# Altium schematic sheet NU-PROG_ESP32.SchDoc(NU-PROG_ESP32)
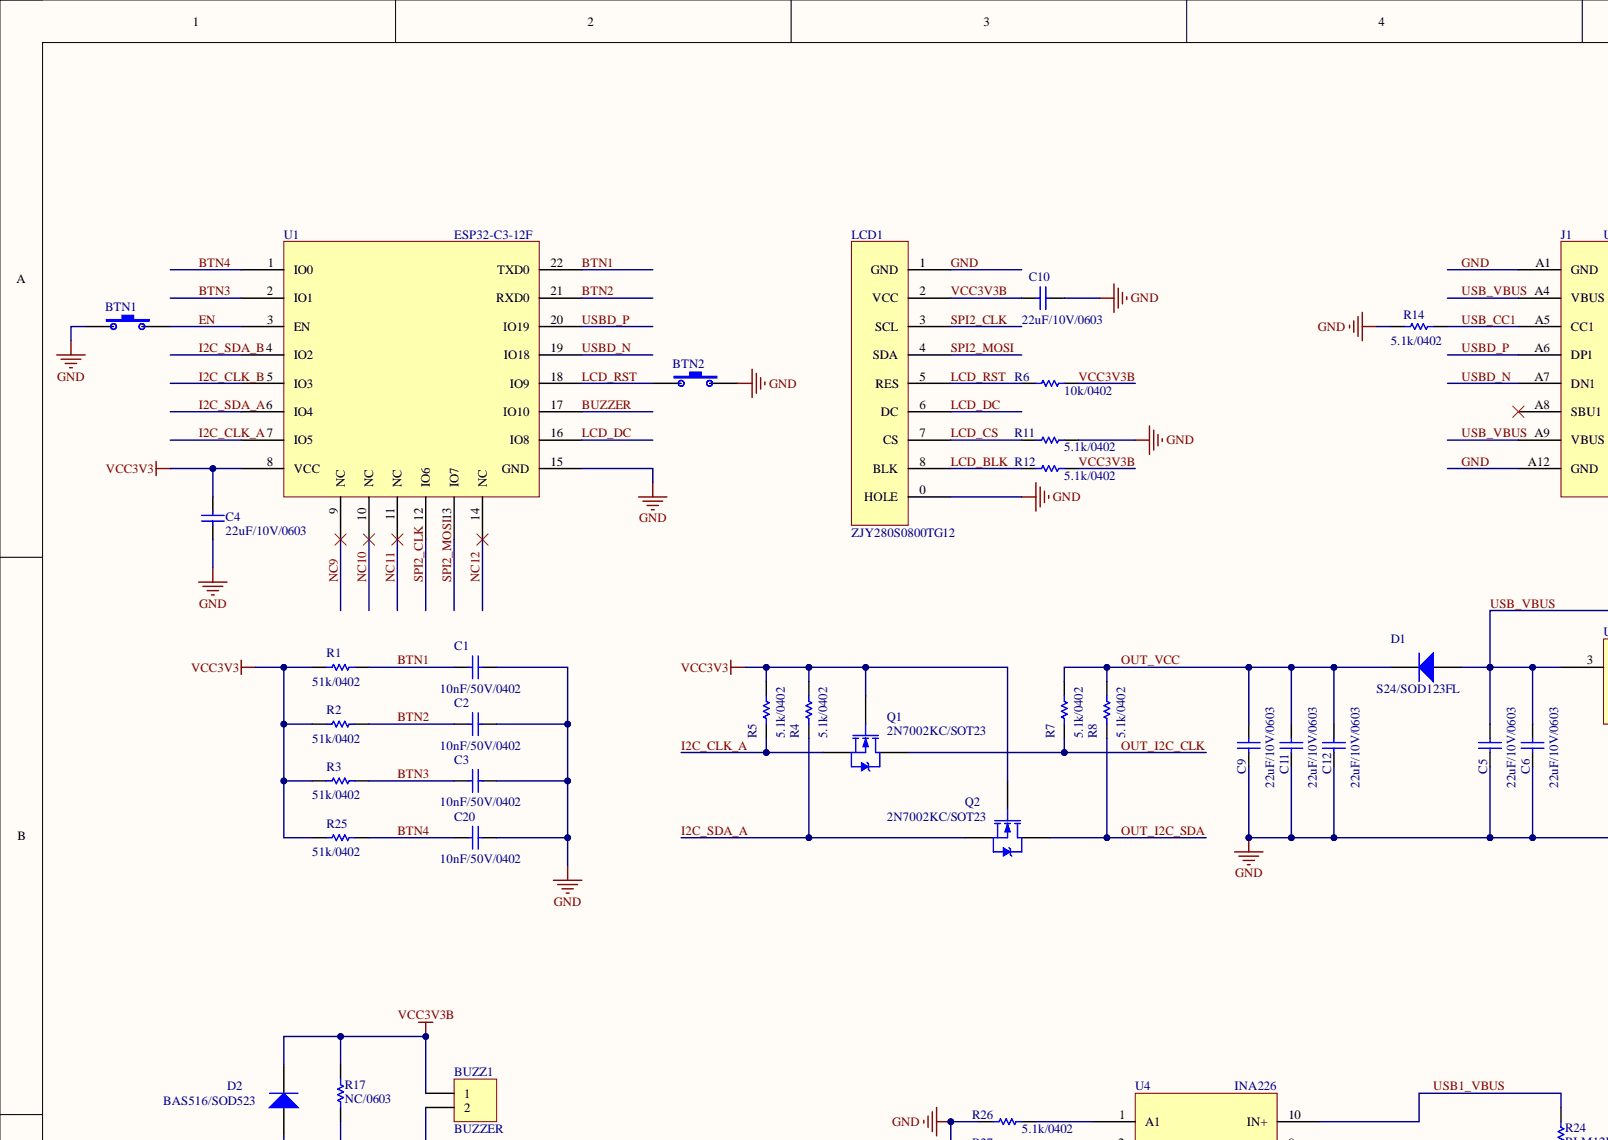
[diagram p0: NU-PROG_ESP32.SchDoc, top left]
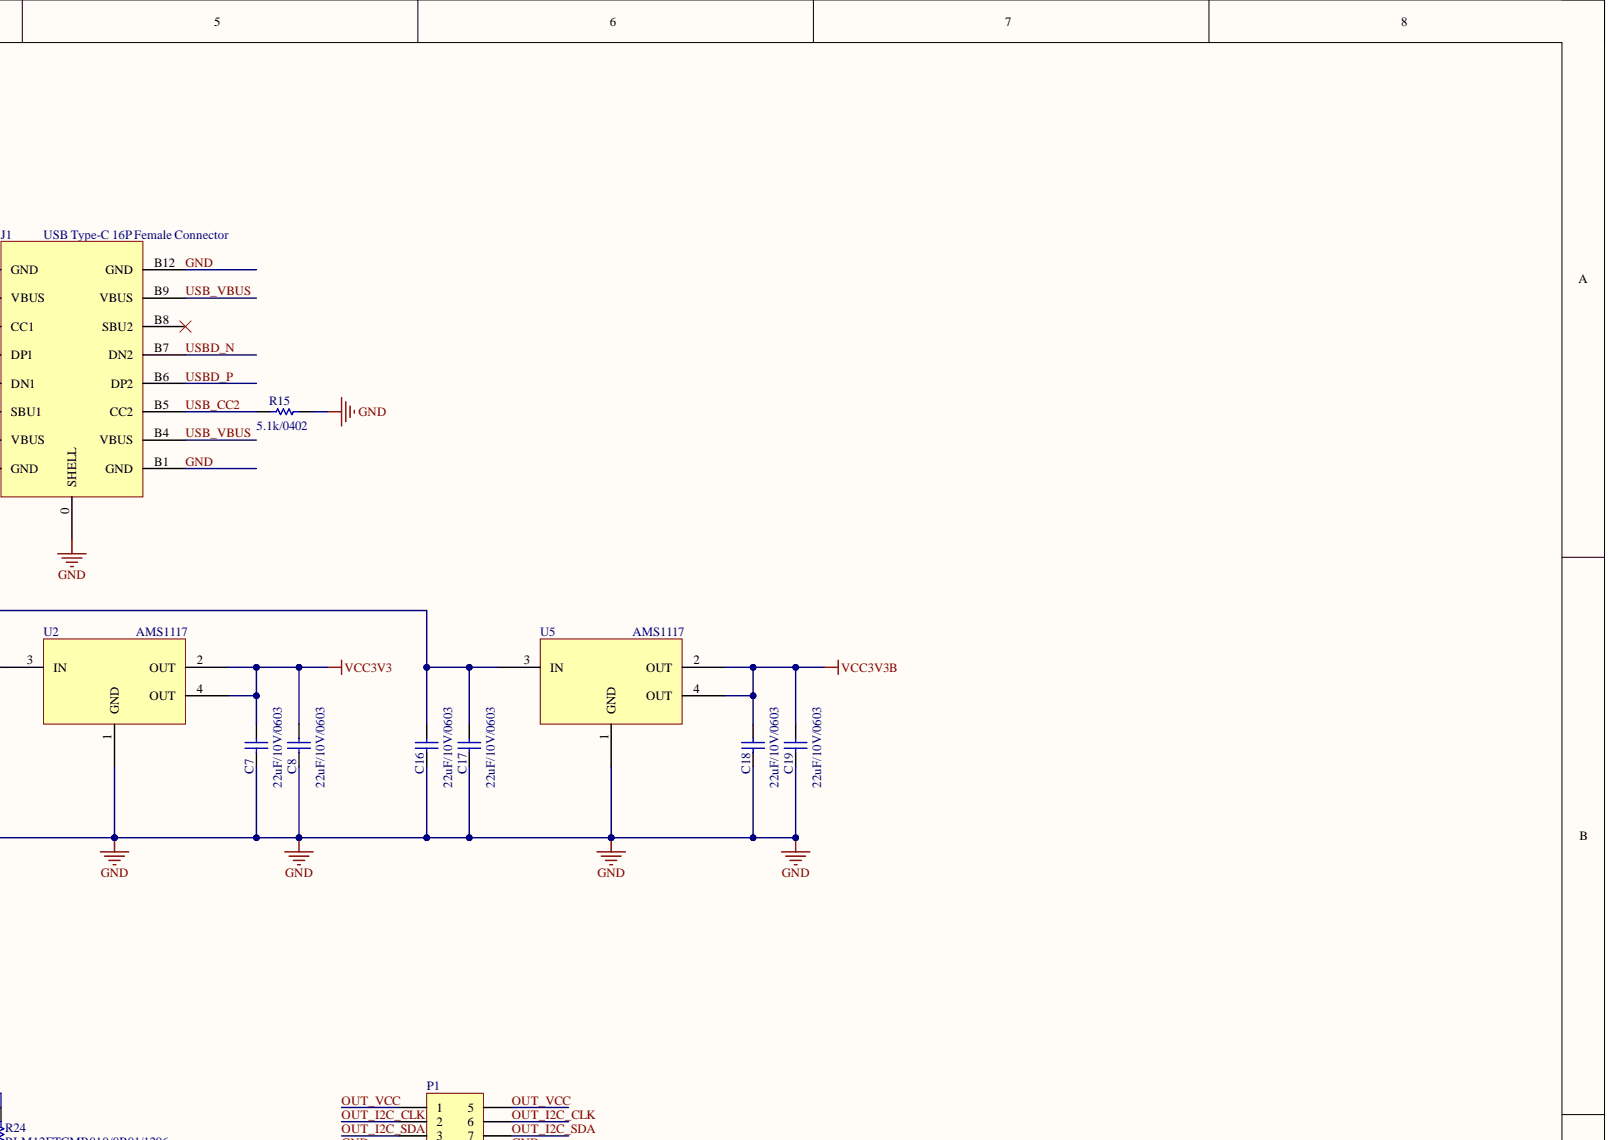
[diagram p1: NU-PROG_ESP32.SchDoc, top right]
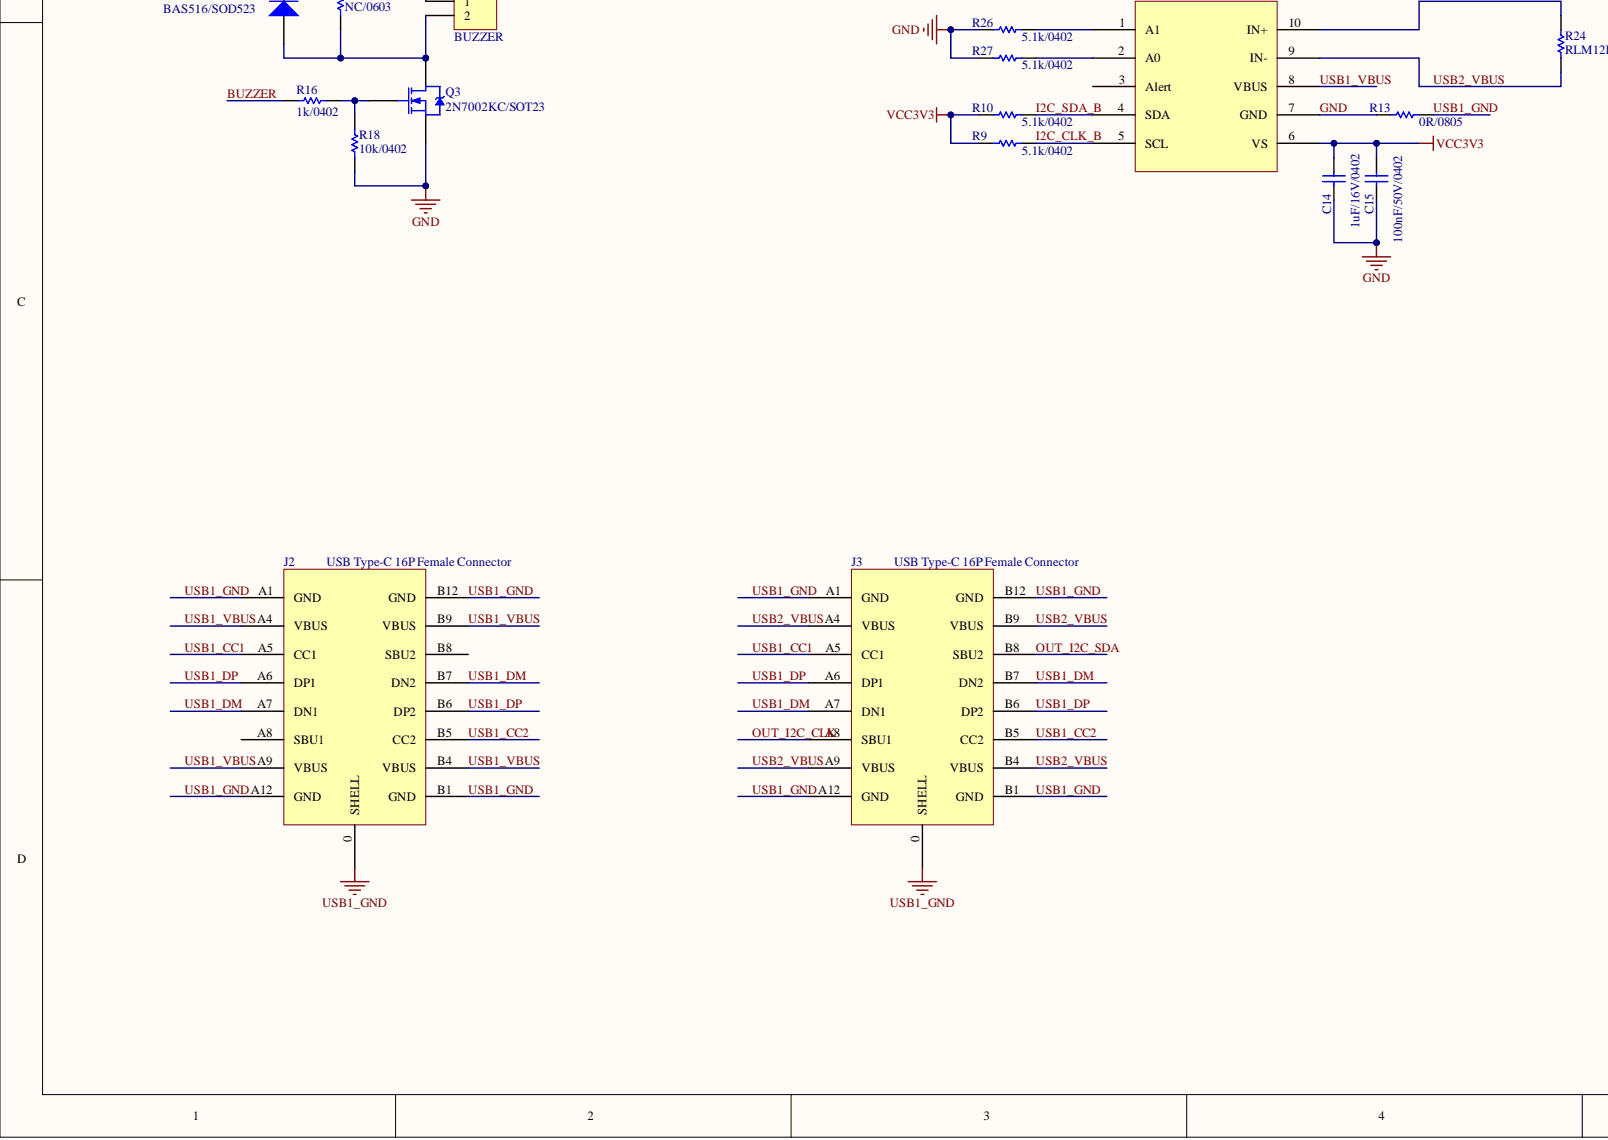
[diagram p2: NU-PROG_ESP32.SchDoc, bottom left]
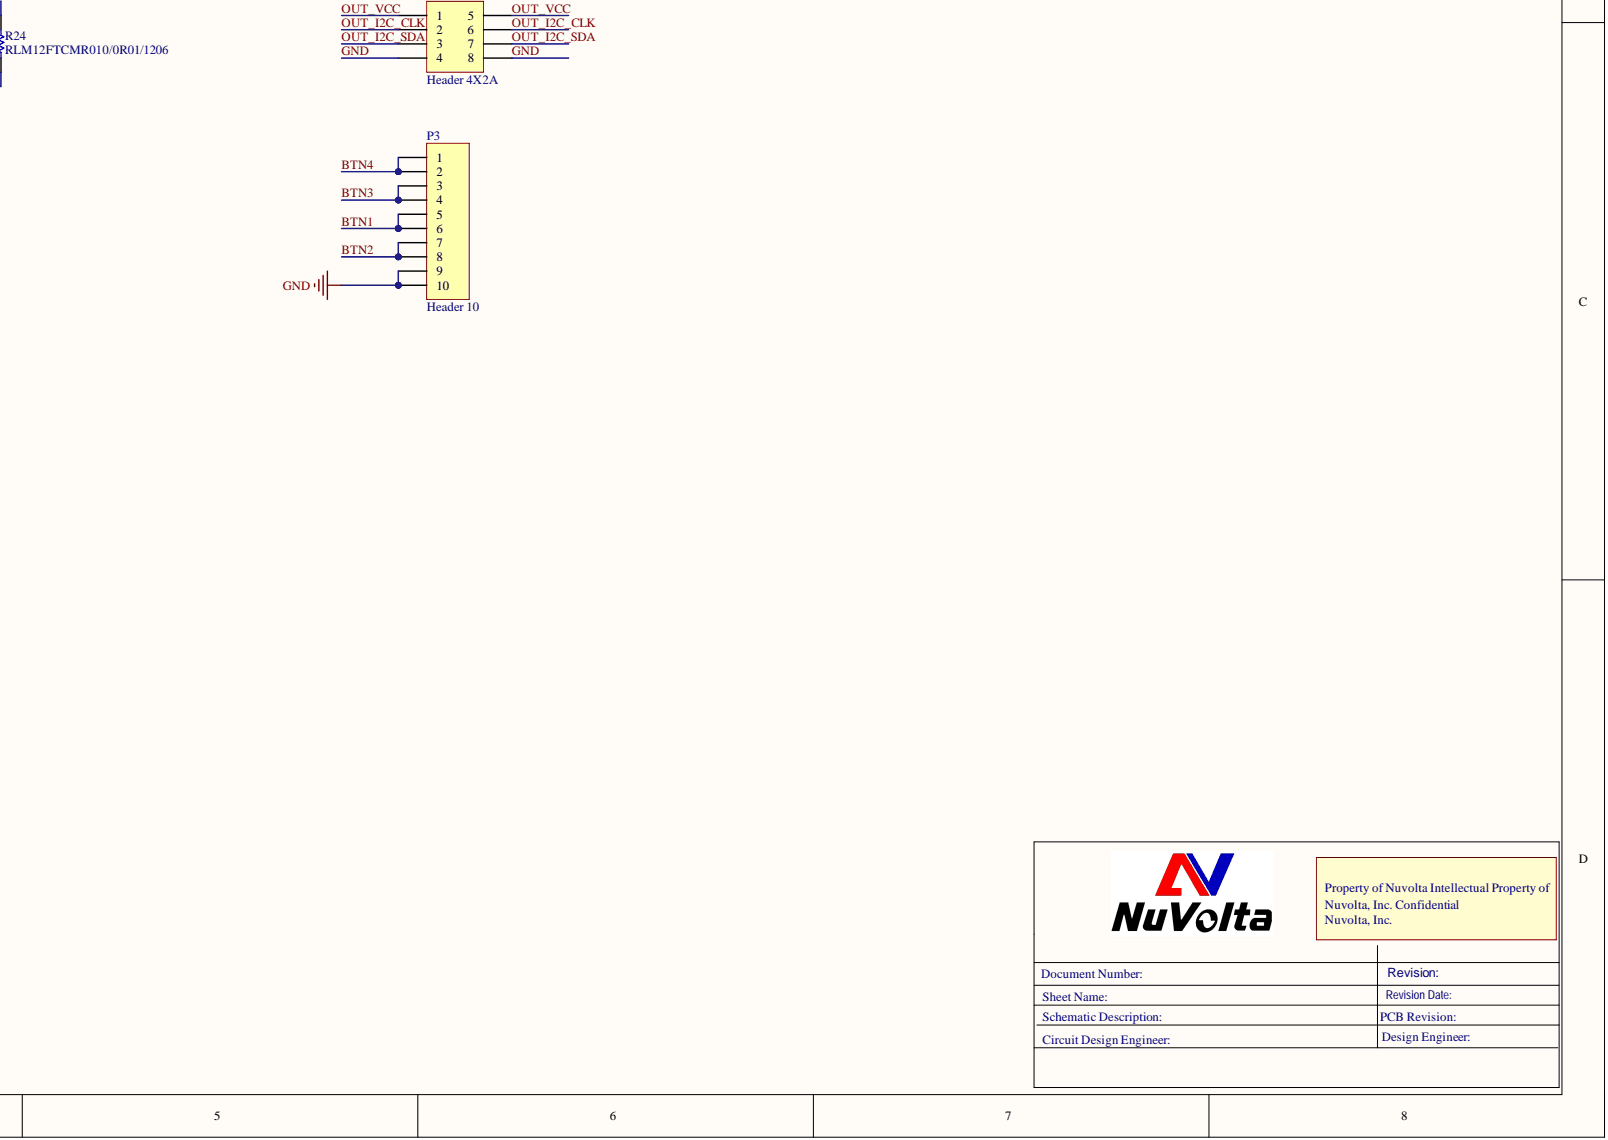
[diagram p3: NU-PROG_ESP32.SchDoc, bottom right]

## Parts
BTN1  Button/GT-TC029X-H020-L1N  [GT-TC029X-H020]  (value not drawn)
BTN2  Button/GT-TC029X-H020-L1N  [GT-TC029X-H020]  (value not drawn)
BUZZ1  BUZZER  [PS1240P02CT3]
C1  10nF/50V/0402  [C0402]
C2  10nF/50V/0402  [C0402]
C3  10nF/50V/0402  [C0402]
C4  22uF/10V/0603  [C0603]
C5  22uF/10V/0603  [C0603]
C6  22uF/10V/0603  [C0603]
C7  22uF/10V/0603  [C0603]
C8  22uF/10V/0603  [C0603]
C9  22uF/10V/0603  [C0603]
C10  22uF/10V/0603  [C0603]
C11  22uF/10V/0603  [C0603]
C12  22uF/10V/0603  [C0603]
C14  1uF/16V/0402  [C0402]
C15  100nF/50V/0402  [C0402]
C16  22uF/10V/0603  [C0603]
C17  22uF/10V/0603  [C0603]
C18  22uF/10V/0603  [C0603]
C19  22uF/10V/0603  [C0603]
C20  10nF/50V/0402  [C0402]
D1  S24/SOD123FL  [SOD-123FL]
D2  BAS516/SOD523  [SOD523]
J1  USB Type-C 16P Female Connector  [USB_C_16P_NEW]
J2  USB Type-C 16P Female Connector  [USB_C_16P_NEW]
J3  USB Type-C 16P Female Connector  [USB_C_16P_NEW]
LCD1  ZJY280S0800TG12  [ZJY280S0800TG12]
P1  Header 4X2A  [HDR2X4_2]
P3  Header 10  [HDR1X10_2]
Q1  2N7002KC/SOT23  [SOT23]
Q2  2N7002KC/SOT23  [SOT23]
Q3  2N7002KC/SOT23  [SOT23]
R1  51k/0402  [R0402]
R2  51k/0402  [R0402]
R3  51k/0402  [R0402]
R4  5.1k/0402  [R0402]
R5  5.1k/0402  [R0402]
R6  10k/0402  [R0402]
R7  5.1k/0402  [R0402]
R8  5.1k/0402  [R0402]
R9  5.1k/0402  [R0402]
R10  5.1k/0402  [R0402]
R11  5.1k/0402  [R0402]
R12  5.1k/0402  [R0402]
R13  0R/0805  [R0805]
R14  5.1k/0402  [R0402]
R15  5.1k/0402  [R0402]
R16  1k/0402  [R0402]
R17  NC/0603  [R0603]
R18  10k/0402  [R0402]
R24  RLM12FTCMR010/0R01/1206  [R1206]
R25  51k/0402  [R0402]
R26  5.1k/0402  [R0402]
R27  5.1k/0402  [R0402]
U1  ESP32-C3-12F  [ESP32-C3-12F]
U2  AMS1117  [SOT223]
U4  INA226  [VSSOP10]
U5  AMS1117  [SOT223]

## Nets
VCC3V3: C4.1 C7.2 C8.2 C14.2 C15.2 Q1.1 Q2.1 R1.1 R2.1 R3.1 R4.2 R5.2 R9.1 R10.1 R25.1 U1.8(VCC) U2.2(OUT) U2.4(OUT) U4.6(VS)
BTN1: C1.2 P3.5 P3.6 R1.2 U1.22(TXD0)
BTN2: C2.2 P3.7 P3.8 R2.2 U1.21(RXD0)
BTN3: C3.2 P3.3 P3.4 R3.2 U1.2(IO1)
BTN4: C20.2 P3.1 P3.2 R25.2 U1.1(IO0)
BUZZER: R16.1 U1.17(IO10)
EN: BTN1.2 U1.3(EN)
GND: BTN1.1 BTN2.2 C1.1 C2.1 C3.1 C4.2 C5.1 C6.1 C7.1 C8.1 C9.1 C10.1 C11.1 C12.1 C14.1 C15.1 C16.1 C17.1 C18.1 C19.1 C20.1 J1.0(SHELL) J1.A1(GND) J1.A12(GND) J1.B1(GND) J1.B12(GND) LCD1.0(HOLE) LCD1.1(GND) P1.4 P1.8 P3.9 P3.10 Q3.2 R11.1 R13.1 R14.1 R15.2 R18.1 R26.1 R27.1 U1.15(GND) U2.1(GND) U4.7(GND) U5.1(GND)
I2C_CLK_A: Q1.2 R5.1 U1.7(IO5)
I2C_CLK_B: R9.2 U1.5(IO3) U4.5(SCL)
I2C_SDA_A: Q2.2 R4.1 U1.6(IO4)
I2C_SDA_B: R10.2 U1.4(IO2) U4.4(SDA)
LCD_BLK: LCD1.8(BLK) R12.2
LCD_CS: LCD1.7(CS) R11.2
LCD_DC: LCD1.6(DC) U1.16(IO8)
LCD_RST: BTN2.1 LCD1.5(RES) R6.2 U1.18(IO9)
NC9: U1.9(NC)
NC10: U1.10(NC)
NC11: U1.11(NC)
NC12: U1.14(NC)
OUT_I2C_CLK: J3.A8(SBU1) P1.2 P1.6 Q1.3 R7.1
OUT_I2C_SDA: J3.B8(SBU2) P1.3 P1.7 Q2.3 R8.1
OUT_VCC: C9.2 C11.2 C12.2 D1.2 P1.1 P1.5 R7.2 R8.2
SPI2_CLK: LCD1.3(SCL) U1.12(IO6)
SPI2_MOSI: LCD1.4(SDA) U1.13(IO7)
USB1_CC1: J2.A5(CC1) J3.A5(CC1)
USB1_CC2: J2.B5(CC2) J3.B5(CC2)
USB1_DM: J2.A7(DN1) J2.B7(DN2) J3.A7(DN1) J3.B7(DN2)
USB1_DP: J2.A6(DP1) J2.B6(DP2) J3.A6(DP1) J3.B6(DP2)
USB1_GND: J2.0(SHELL) J2.A1(GND) J2.A12(GND) J2.B1(GND) J2.B12(GND) J3.0(SHELL) J3.A1(GND) J3.A12(GND) J3.B1(GND) J3.B12(GND) R13.2
USB1_VBUS: J2.A4(VBUS) J2.A9(VBUS) J2.B4(VBUS) J2.B9(VBUS) R24.2 U4.8(VBUS) U4.10(IN+)
USB2_VBUS: J3.A4(VBUS) J3.A9(VBUS) J3.B4(VBUS) J3.B9(VBUS) R24.1 U4.9(IN-)
USB_CC1: J1.A5(CC1) R14.2
USB_CC2: J1.B5(CC2) R15.1
USB_VBUS: C5.2 C6.2 C16.2 C17.2 D1.1 J1.A4(VBUS) J1.A9(VBUS) J1.B4(VBUS) J1.B9(VBUS) U2.3(IN) U5.3(IN)
USBD_N: J1.A7(DN1) J1.B7(DN2) U1.19(IO18)
USBD_P: J1.A6(DP1) J1.B6(DP2) U1.20(IO19)
VCC3V3B: BUZZ1.1 C10.2 C18.2 C19.2 D2.2 LCD1.2(VCC) R6.1 R12.1 R17.2 U5.2(OUT) U5.4(OUT)
NetBUZZ1_2: BUZZ1.2 D2.1 Q3.3 R17.1
NetQ3_1: Q3.1 R16.2 R18.2
NetR26_2: R26.2 U4.1(A1)
NetR27_2: R27.2 U4.2(A0)

Not connected: J1 A8(SBU1) B8(SBU2); J2 A8(SBU1) B8(SBU2); U4 3(Alert)

## Library data of the parts
BTN1 BTN2 = J00000042: Manufacturer: G-Switch; Database Table Name: Other$; NuVolta PN: /; Unique: TRUE; Component Kind: Standard; Component MPN: GT-TC029X-H020-L1N; Description: Button/GT-TC029X-H020-L1N; Index: J00000042; Package: SMD; Value: Button/GT-TC029X-H020-L1N; Library Name: NVT.DbLib
BUZZ1 = Header 2: Header, 2-Pin; Component Kind: Standard; LatestRevisionDate: 17-Jul-2002; LatestRevisionNote: Re-released for DXP Platform.; Library Name: Miscellaneous Connectors.IntLib; Signal Integrity: Connector; Publisher: Altium Limited
C1-C3 C20 = C00000015: CAP CER/10nF/50V/X7R/0402/10%; Component MPN: /; Database Table Name: Capacitors$; Unique: True; Component Kind: Standard; Index: C00000015; Manufacturer: /; NuVolta PN: /; Package: 0402; Value: 10nF/50V/0402; Library Name: NVT.DbLib
C4-C12 C16-C19 = C00000090: CAP CER/22uF/10V/X5R/0603/20%; Component MPN: /; Database Table Name: Capacitors$; Unique: True; Component Kind: Standard; Index: C00000090; Manufacturer: /; NuVolta PN: /; Package: 0603; Value: 22uF/10V/0603; Library Name: NVT.DbLib
C14 = C00000021: CAP CER/1uF/16V/X5R/0402/10%; Component MPN: /; Database Table Name: Capacitors$; Unique: True; Component Kind: Standard; Index: C00000021; Manufacturer: /; NuVolta PN: /; Package: 0402; Value: 1uF/16V/0402; Library Name: NVT.DbLib
C15 = C00000095: CAP CER/100nF/50V/X7R/0402/10%; Component MPN: /; Database Table Name: Capacitors$; Unique: True; Component Kind: Standard; Index: C00000095; Manufacturer: /; NuVolta PN: /; Package: 0402; Value: 100nF/50V/0402; Library Name: NVT.DbLib
D1 = D00000034: DIODE SCHOTTKY/40V 2A/SOD-123FL; Component MPN: S24; Manufacturer: YANGJIE; Database Table Name: Diodes$; NuVolta PN: /; Unique: TRUE; Component Kind: Standard; Index: D00000034; Package: SOD-123FL; Value: S24/SOD123FL; Library Name: NVT.DbLib
D2 = D00000010: DIODE SWITCHING/75V 250mA/SOD523; Component MPN: BAS516; Manufacturer: CJ; Database Table Name: Diodes$; NuVolta PN: /; Unique: TRUE; Component Kind: Standard; Index: D00000010; Package: SOD523; Value: BAS516/SOD523; Library Name: NVT.DbLib
J1-J3 = J00000004: USB Type C Female Connector/16P/White; Component MPN: /; Manufacturer: JINSHENGXIN; Database Table Name: Other$; Unique: TRUE; Component Kind: Standard; Index: J00000004; NuVolta PN: /; Package: SMT; value: USB Type-C 16P Female Connector; Library Name: NVT.DbLib
P1 = Header 4X2A: Header, 4-Pin, Dual row; Component Kind: Standard; LatestRevisionDate: 17-Jul-2002; LatestRevisionNote: Re-released for DXP Platform.; Library Name: Miscellaneous Connectors.IntLib; Signal Integrity: Connector; Publisher: Altium Limited
P3 = Header 10: Header, 10-Pin; Component Kind: Standard; LatestRevisionDate: 17-Jul-2002; LatestRevisionNote: Re-released for DXP Platform.; Library Name: Miscellaneous Connectors.IntLib; Signal Integrity: Connector; Publisher: Altium Limited
Q1-Q3 = T00000030: TRAN NMOS/60V 300mA/3ohm@4.5V/SOT23; Manufacturer: YANGJIE; Database Table Name: Transistors$; NuVolta PN: /; Unique: TRUE; Component Kind: Standard; Component MPN: 2N7002KC; Index: T00000030; Package: SOT23; Value: 2N7002KC/SOT23; Library Name: NVT.DbLib
R1-R3 R25 = R00000034: RES SMD/51k/0402/1%; Component MPN: /; Database Table Name: Resisters$; Unique: True; Component Kind: Standard; Index: R00000034; Manufacturer: /; NuVolta PN: /; Package: 0402; Value: 51k/0402; Library Name: NVT.DbLib
R4 R5 R7-R12 R14 R15 R26 R27 = R00000022: RES SMD/5.1k/0402/1%; Component MPN: /; Database Table Name: Resisters$; Unique: True; Component Kind: Standard; Index: R00000022; Manufacturer: /; NuVolta PN: /; Package: 0402; Value: 5.1k/0402; Library Name: NVT.DbLib
R6 R18 = R00000002: RES SMD/10k/0402/1%; Component MPN: /; Database Table Name: Resisters$; Unique: True; Component Kind: Standard; Index: R00000002; Manufacturer: /; NuVolta PN: /; Package: 0402; Value: 10k/0402; Library Name: NVT.DbLib
R13 = R00000027: RES SMD/0R/0805/5%; Component MPN: /; Database Table Name: Resisters$; Unique: True; Component Kind: Standard; Index: R00000027; Manufacturer: /; NuVolta PN: /; Package: 0805; Value: 0R/0805; Library Name: NVT.DbLib
R16 = R00000063: RES SMD/1k/0402/1%; Component MPN: /; Database Table Name: Resisters$; Unique: True; Component Kind: Standard; Index: R00000063; Manufacturer: /; NuVolta PN: /; Package: 0402; Value: 1k/0402; Library Name: NVT.DbLib
R17 = R00000070: RES SMD/NC/0603; Component MPN: /; Database Table Name: Resisters$; Unique: True; Component Kind: Standard; Index: R00000070; Manufacturer: /; NuVolta PN: /; Package: 0603; Value: NC/0603; Library Name: NVT.DbLib
R24 = R00000098: RES SMD/0R01/1206/1%/1W/50PPM/℃; Manufacturer: TA-I; Database Table Name: Resisters$; NuVolta PN: /; Unique: True; Component Kind: Standard; Component MPN: RLM12FTCMR010; Index: R00000098; Package: 1206; Value: RLM12FTCMR010/0R01/1206; Library Name: NVT.DbLib
LCD1 = ZJY280S0800TG12: Component Kind: Standard; value: ZJY280S0800TG12; Library Name: NU-PROG_ESP32.SchLib
U1 = ESP32-C3-12F: Component Kind: Standard; value: ESP32-C3-12F; Library Name: NU-PROG_ESP32.SchLib
U2 U5 = AMS1117: Component Kind: Standard; value: AMS1117; Library Name: NU-PROG_ESP32.SchLib
U4 = INA226: Component Kind: Standard; value: INA226; Library Name: NU-PROG_ESP32.SchLib
